# Altium schematic sheet 电机驱动.SchDoc(电机驱动)
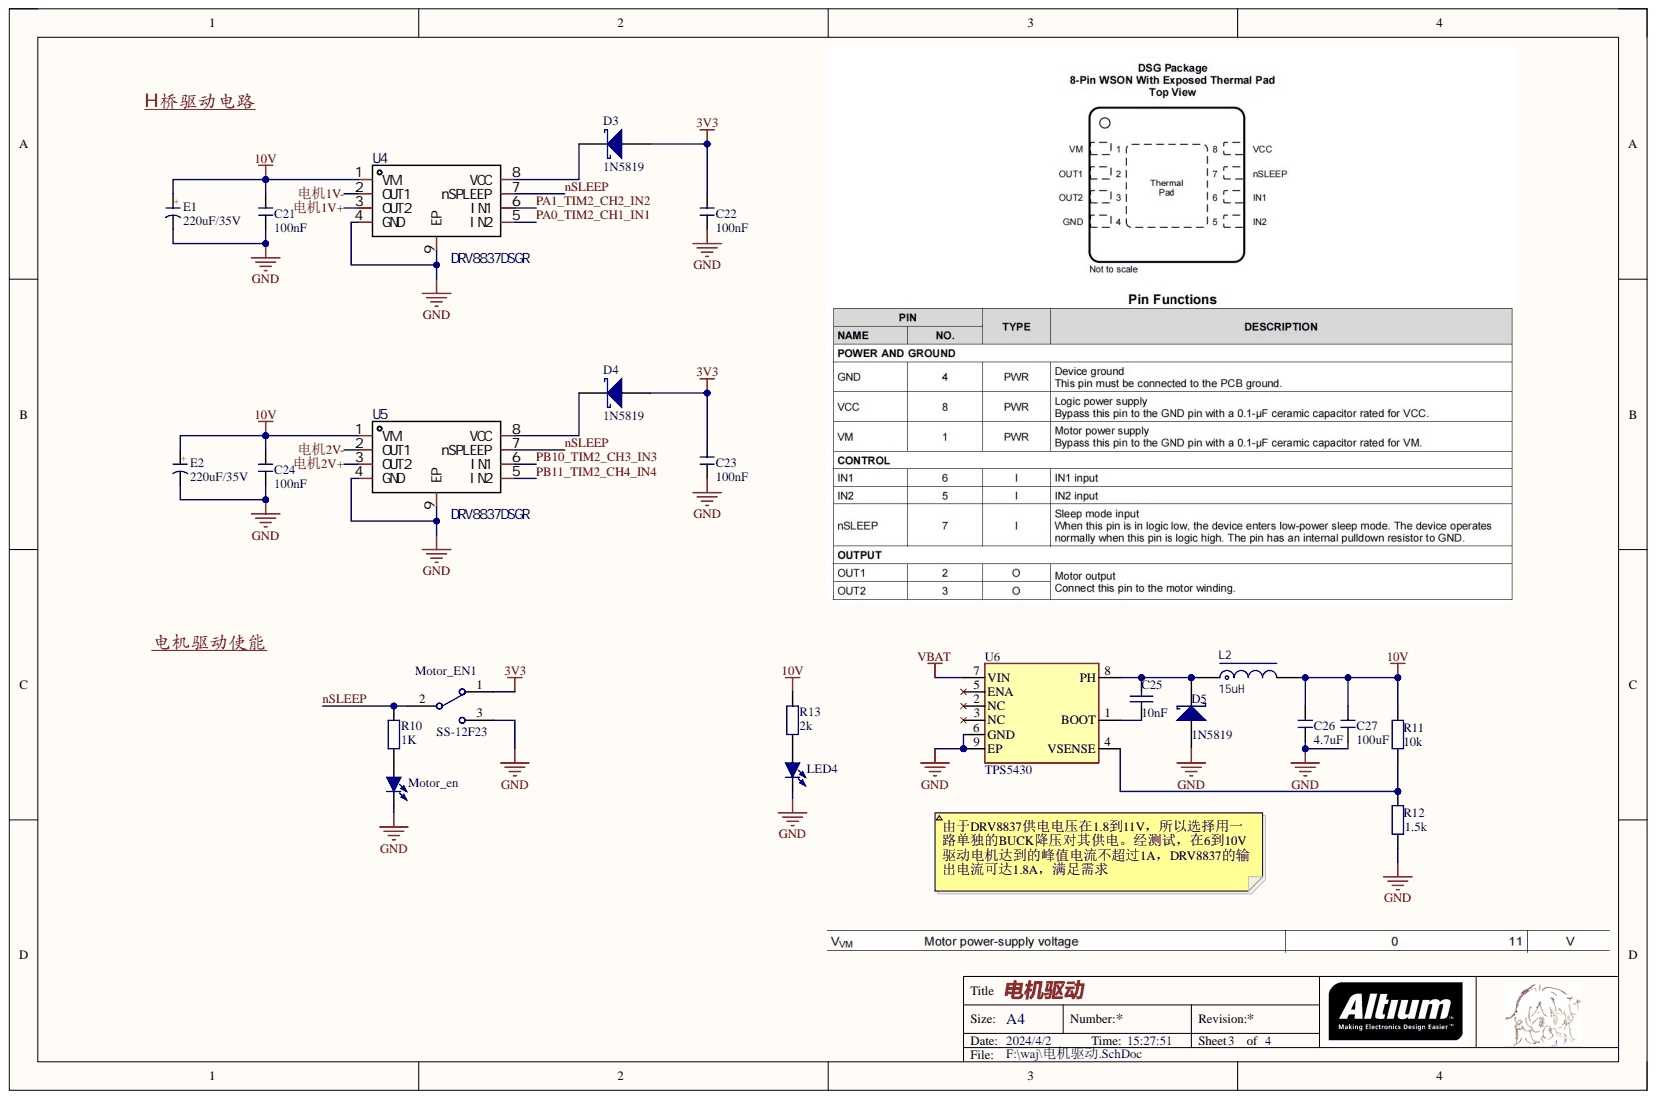
[diagram p0: 电机驱动.SchDoc]

## Parts
C21  100nF  [C 0603_L]
C22  100nF  [C 0603_L]
C23  100nF  [C 0603_L]
C24  100nF  [C 0603_L]
C25  10nF  [C 0603_M]
C26  4.7uF  [C 1210_L]
C27  100uF  [C 1210_L]
D3  1N5819  [SMA]
D4  1N5819  [SMA]
D5  1N5819  [SMA]
E1  220uF/35V  [CM E(8*10.2)]
E2  220uF/35V  [CM E(8*10.2)]
L2  15uH  [NR4030]
LED4  红色  [LED 0603W]  (value not drawn)
Motor_en  红色  [LED 0603W]  (value not drawn)
Motor_EN1  SS-12F23  [MSK-12C01-07]
R10  1K  [R 0603_L]
R11  10k  [R 0603_M]
R12  1.5k  [R 0603_M]
R13  2k  [R 0603_L]
U4  DRV8837DSGR  [DRV8837]
U5  DRV8837DSGR  [DRV8837]
U6  TPS5430  [SOIC-8-EP]

## Nets
3V3: C22.2 C23.2 D3.1 D4.1 Motor_EN1.1
10V: C21.2 C24.2 C26.2 C27.2 E1.1 E2.1 L2.2 R11.1 R13.2 U4.1 U5.1
GND: C21.1 C22.1 C23.1 C24.1 C26.1 C27.1 D5.1 E1.2 E2.2 LED4.2 Motor_en.2 Motor_EN1.3 R12.2 U4.4 U4.9 U5.4 U5.9 U6.6 U6.9
VBAT: U6.7
nSLEEP: Motor_EN1.2 R10.2 U4.7 U5.7
PA0_TIM2_CH1_IN1: U4.5
PA1_TIM2_CH2_IN2: U4.6
PB10_TIM2_CH3_IN3: U5.6
PB11_TIM2_CH4_IN4: U5.5
电机1V+: U4.3
电机1V-: U4.2
电机2V+: U5.3
电机2V-: U5.2
NetC25_1: C25.1 U6.1
NetC25_2: C25.2 D5.2 L2.1 U6.8
NetD3_2: D3.2 U4.8
NetD4_2: D4.2 U5.8
NetLED4_1: LED4.1 R13.1
NetMotor_en_1: Motor_en.1 R10.1
NetR11_2: R11.2 R12.1 U6.4

Not connected: U6 2 3 5

## Library data of the parts
C21-C24 C26 C27 = C: 贴片电容; BOM名称: 无极性贴片电容; 淘宝ID: 封装库来自淘宝 ID：黄晶宝石; Component Kind: Standard; Library Name: 0.1 - 电阻-电容-电感.SchLib; 唯一出售店铺网址: https://shop463601444.taobao.com; 联系售后，技术支持: https://shop463601444.taobao.com
C25 = Cap: Capacitor; Component Kind: Standard; Library Name: Miscellaneous Devices.IntLib; Signal Integrity: Cap; Simulation: CAP
D3-D5 = Diode 11DQ03: Schottky Rectifier; Component Kind: Standard; ComponentLink1Description: Manufacturer Link; ComponentLink1URL: http://www.irf.com/; ComponentLink2Description: Datasheet; ComponentLink2URL: http://www.irf.com/product-info/datasheets/data/11dq03.pdf; Library Name: Miscellaneous Devices.IntLib; Simulation: 11DQ03
E1 E2 = CE_SMD: 贴片电解电容; 版权归属: 封装库来自淘宝 ID：黄晶宝石; BOM名称: 贴片电解电容; Component Kind: Standard; 联系售后: https://shop463601444.taobao.com; Library Name: 数控电源V3.0.SCHLIB
L2 = L-NR: NR磁胶电感; BOM名称: NR磁胶电感; Component Kind: Standard; Library Name: 钝角.SCHLIB
LED4 Motor_en = LED-SMD: 贴片LED; 版权归属: 封装库来自淘宝 ID：黄晶宝石; BOM名称: 贴片LED; Component Kind: Standard; Library Name: 0.3 - LED发光二极管.SchLib; 学习资源: https://shop463601444.taobao.com
Motor_EN1 = SSW-1P: 1路波动开关; BOM名称: 波动开关; 版权归属: 封装库来自淘宝 ID：黄晶宝石; Component Kind: Standard; Library Name: 0.7 - 常用按键-开关.SchLib; 学习资源: https://shop463601444.taobao.com
R10 R13 = R: 贴片电阻; 封装库来自淘宝卖家 ID：黄晶宝石: 其他店铺购入均为盗版，不享受售后技术支持服务，请立即申请退款; 版权归属: 来自淘宝 ID：黄晶宝石; BOM名称: 贴片电阻; Component Kind: Standard; Library Name: 0.1 - 电阻-电容-电感.SchLib; 联系售后: https://shop463601444.taobao.com
R11 R12 = Res2: Resistor; Component Kind: Standard; Library Name: Miscellaneous Devices.IntLib; Signal Integrity: Res; Simulation: RESISTOR
U4 U5 = DRV8837DSGR: 驱动电机类型:有刷直流电机;电源电压:1.8V~7V;输出电流:1.8A;接口:PWM;; Manufacturer: TI(德州仪器); Add into BOM: yes; Drive motor type: 有刷直流电机; Interface: PWM; Output Current: 1.8A; Component Kind: Standard; Convert to PCB: yes; Datasheet: https://www.ti.com/cn/lit/gpn/drv8837; Device: DRV8837DSGR; JLCPCB Part Class: 扩展库; LCSC Part Name: 1.8A 有刷直流电机驱动芯片 7V; Library Name: DRV8837.SCHLIB; Manufacturer Part: DRV8837DSGR; Name: DRV8837DSGR; Operating Temperature: -40℃~+85℃@(Ta); PCB3D: WSON-8_L2.0-W2.0-H0.8-P0.50; Supplier: LCSC; Supplier Footprint: WSON-8-EP(2x2); Supplier Part: C39159; Supply Voltage: 1.8V~7V; Symbol: DRV8837DSGR
U6 = TPS5430: Component Kind: Standard; Library Name: my_library.SchLib
